# Altium schematic sheet LinearFaders.SchDoc
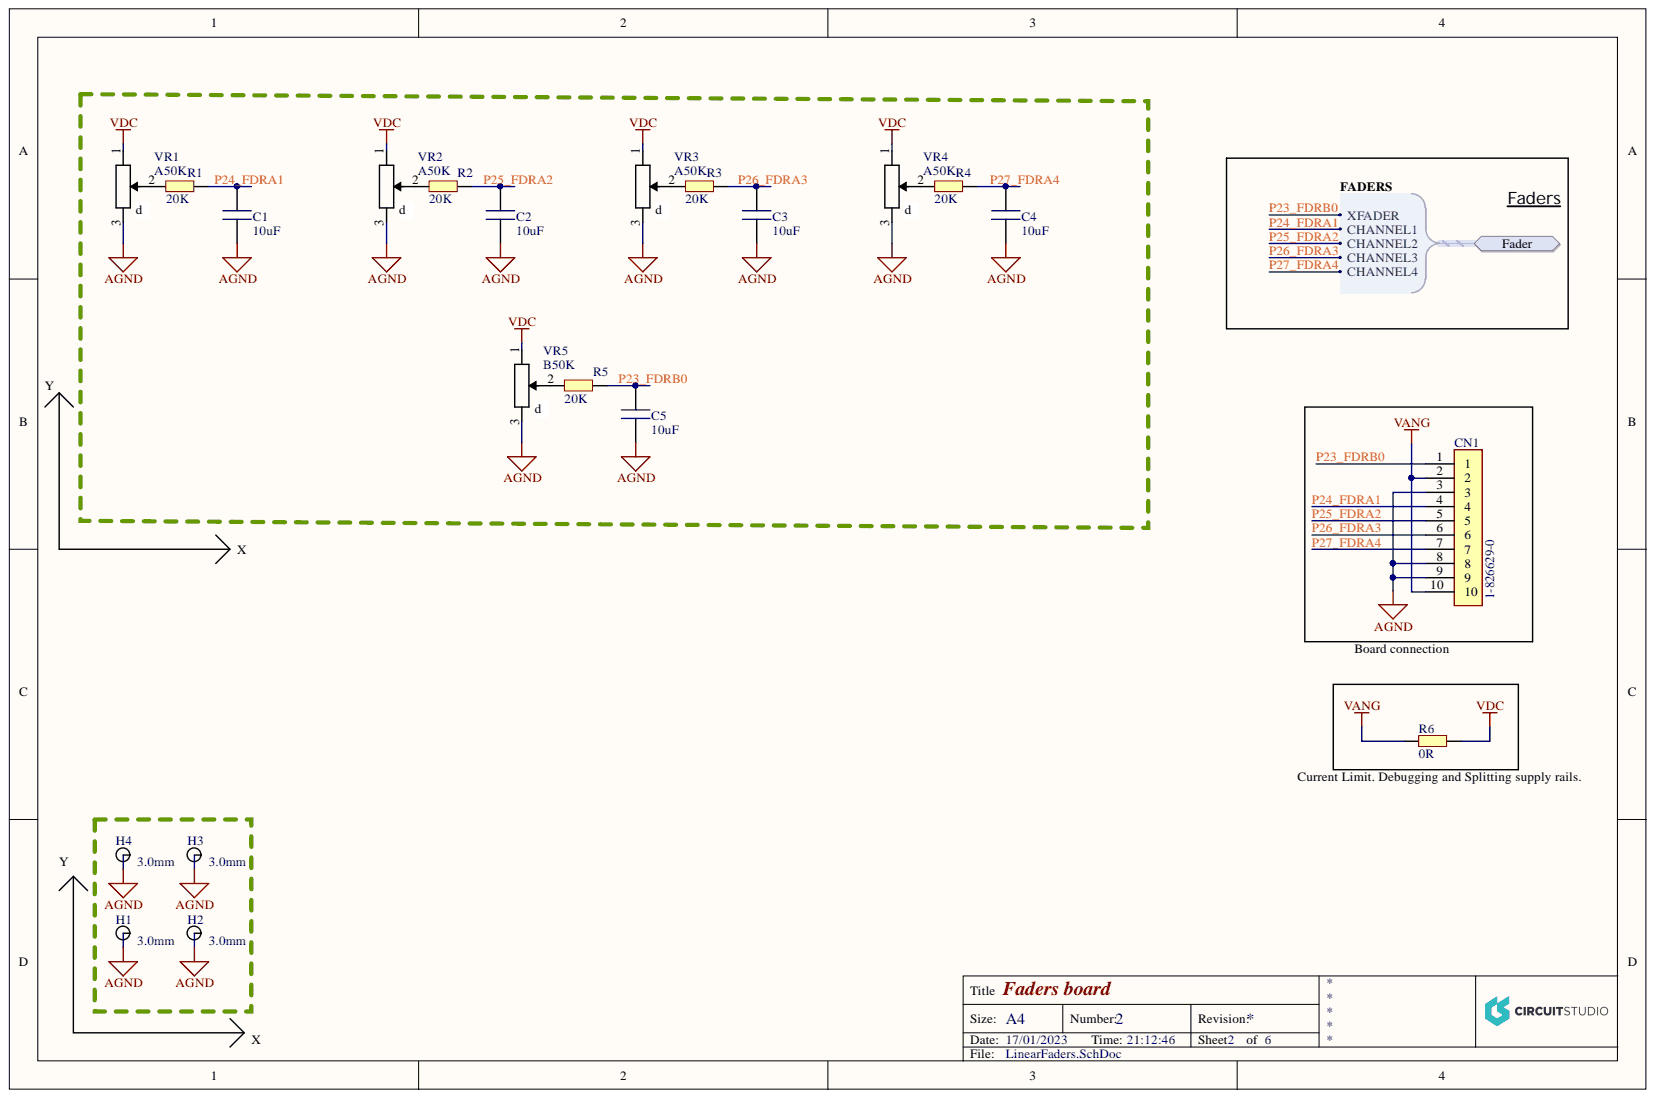
[diagram p0: LinearFaders.SchDoc]

## Parts
C1  10uF  [0603]
C2  10uF  [0603]
C3  10uF  [0603]
C4  10uF  [0603]
C5  10uF  [0603]
CN1  1-826629-0  [TE_1-826629-0]
H1  3.0mm  [HOLE-3.0MM+PAD]
H2  3.0mm  [HOLE-3.0MM+PAD]
H3  3.0mm  [HOLE-3.0MM+PAD]
H4  3.0mm  [HOLE-3.0MM+PAD]
R1  20K  [0603]
R2  20K  [0603]
R3  20K  [0603]
R4  20K  [0603]
R5  20K  [0603]
R6  0R  [0603]
VR1  A50K  [PTA4543]
VR2  A50K  [PTA4543]
VR3  A50K  [PTA4543]
VR4  A50K  [PTA4543]
VR5  B50K  [PTA4543]

## Ports
FADER, FADER.CHANNEL1, FADER.CHANNEL2, FADER.CHANNEL3, FADER.CHANNEL4, FADER.XFADER

## Nets
AGND: C1.1 C2.1 C3.1 C4.1 C5.1 CN1.3 CN1.8 CN1.9 H1.1 H2.1 H3.1 H4.1 VR1.3 VR2.3 VR3.3 VR4.3 VR5.3
VANG: CN1.2 CN1.10 R6.1
VDC: R6.2 VR1.1 VR2.1 VR3.1 VR4.1 VR5.1
P23_FDRB0: C5.2 CN1.1 R5.2 ; port FADER
P24_FDRA1: C1.2 CN1.4 R1.2 ; port FADER
P25_FDRA2: C2.2 CN1.5 R2.2 ; port FADER
P26_FDRA3: C3.2 CN1.6 R3.2 ; port FADER
P27_FDRA4: C4.2 CN1.7 R4.2 ; port FADER
NetR1_1: R1.1 VR1.2
NetR2_1: R2.1 VR2.2
NetR3_1: R3.1 VR3.2
NetR4_1: R4.1 VR4.2
NetR5_1: R5.1 VR5.2
N00002:

## Library data of the parts
C1-C5 = CAP 0603 10uF: 16V 10uF X5R ±20% 0603 MLCC; MN: Samwha Capacitor; MP: CS1608X5R106M160NRB; Component Kind: Standard; Dielectric: X5R; LCSC: C2909630; Library Name: [0002]CAP0603_SMD_10uF.IntLib; Tolerance: 20%; Value: 10uF
CN1 = 1-826629-0: Conn Unshrouded Header HDR 10 POS 2.54mm Solder ST Thru-Hole Carton; MF: TE Connectivity; Availability: Unavailable; Component Kind: Standard; Library Name: [0503]HEADER10X1X2_54_TH_ST.IntLib; MP: 1-826629-0; Package: None; Price: None; SnapEDA_Link: https://www.snapeda.com/parts/1-826629-0/TE%20Connectivity%20AMP%20Connectors/view-part/2877207/?ref=snap
H1-H4 = 3.0MM HOLE+6mmPAD: Component Kind: Standard; Description: 3.0mm HOLE+6mmPAD; Value: 3.0mm; Library Name: [1001]HOLE_PLATED_3MM.IntLib
R1-R5 = RES0603_20K: 0603 Standard 20K Resistor, 1%, 100mW, ±200ppm/℃,Thick Film; MN: Resistor.Today; MP: ETCR0603F20K0K9; UA P/N: 13-0004; Component Kind: Standard; LCSC: C365403; Library Name: [0001]RES0603_SMD_20K.IntLib; Type: THICK FILM; Value: 20K
R6 = RES0603_0R: 0603 Standard 0R Resistor, 1%, 100mW, ±200ppm/℃,Thick Film; MN: YAGEO; MP: AF0603FR-070RL; UA P/N: 13-0004; Component Kind: Standard; LCSC: C192909; Library Name: [0001]RES0603_SMD_0R.IntLib; Type: THICK FILM; Value: 0R
VR1-VR4 = PTA4543-2015CPA503: Slide Potentiometers PANEL CONTROL - SLIDE CARBON; MN: Bourns; Component Kind: Standard; Library Name: [0009]PTA4543-2015CPA503.IntLib; Mouser: 652-PA45432015CPA503; MP: PTA4543-2015CPA503; Price: £1.45; Value: A50K
VR5 = PTA4543-2015CPB503: Slide Potentiometers PANEL CONTROL - SLIDE CARBON; MN: Bourns; Component Kind: Standard; Library Name: [0009]PTA4543-2015CPB503.IntLib; Mouser: 652-PA45532015CPB503; MP: PTA4543-2015CPB503; Price: £1.39; Value: B50K
